# Altium schematic sheet BMS.SchDoc
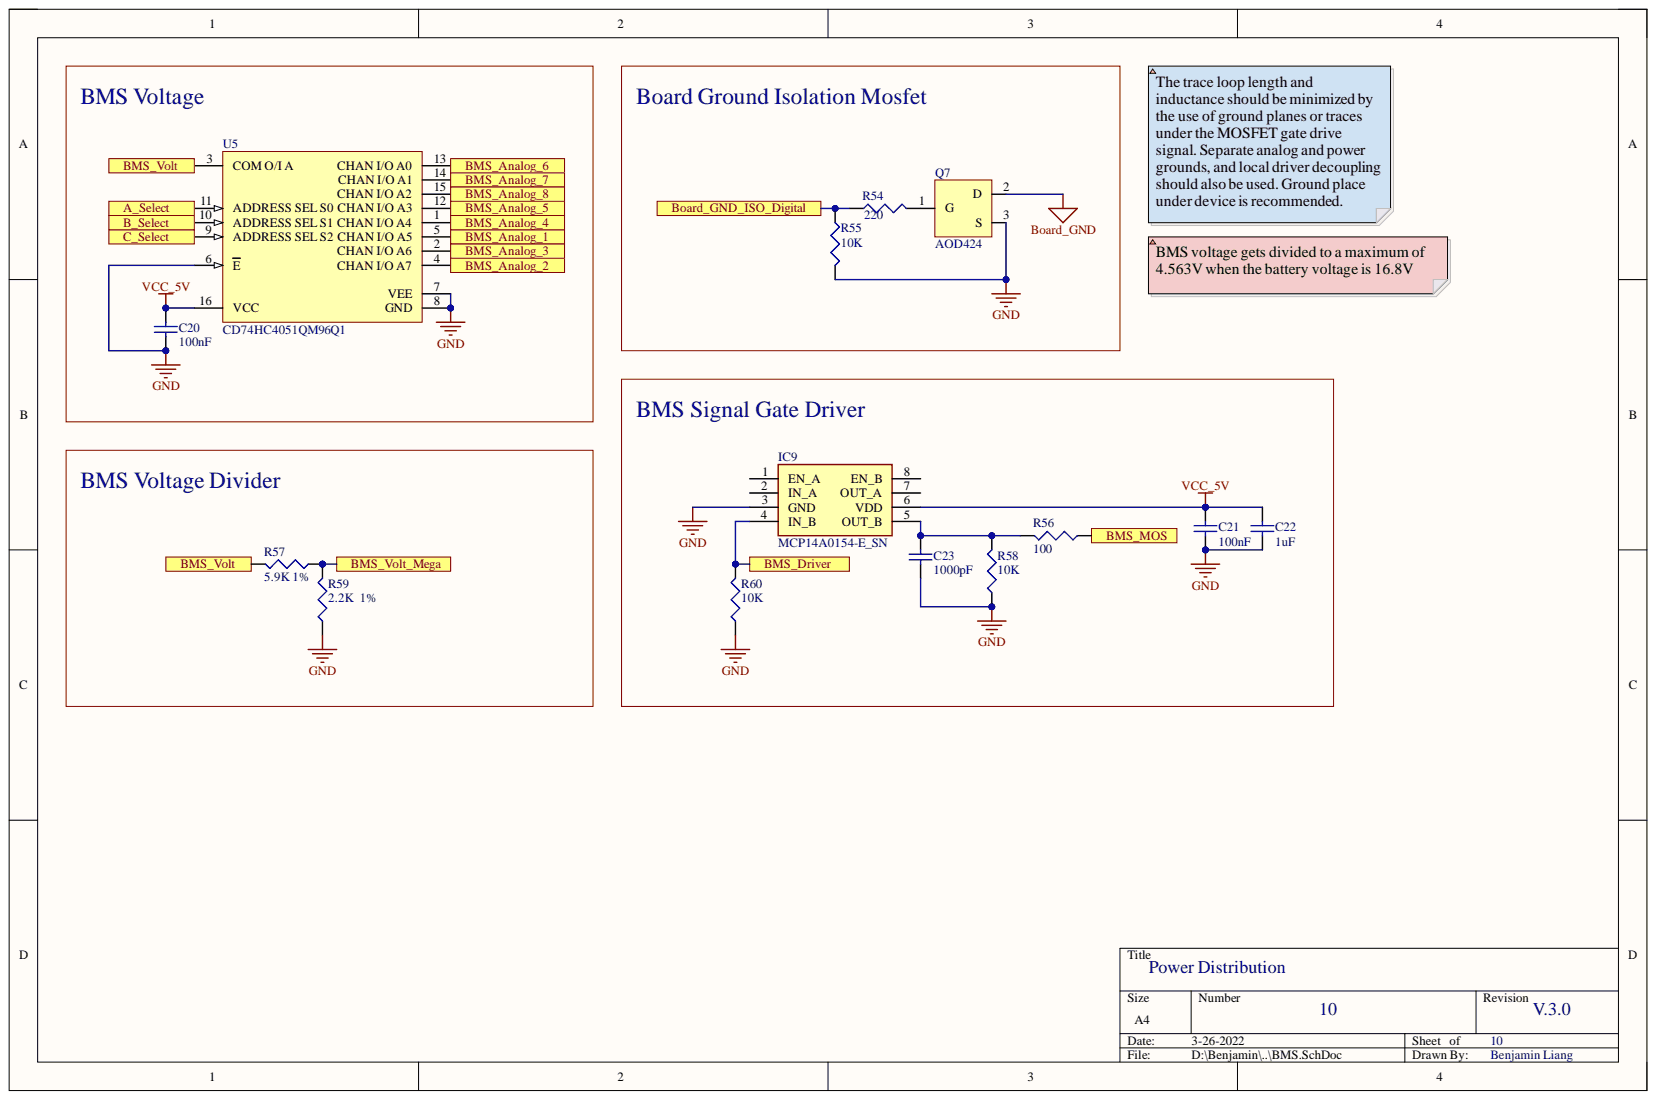
[diagram p0: BMS.SchDoc]

## Parts
C20  100nF  [C1206]
C21  100nF  [C1206]
C22  1uF  value 100nF  [C1206]
C23  1000pF  [C1206]
IC9  MCP14A0154-E_SN  [SOIC127P599X173-8N]
Q7  AOD424  [DPAK228P998X240-3N]
R54  220  [J1-0603]
R55  10K  [J1-0603]
R56  100  [J1-0603]
R57  5.9K 1%  [J1-0603]
R58  10K  [J1-0603]
R59  2.2K  1%  [J1-0603]
R60  10K  [J1-0603]
U5  CD74HC4051QM96Q1  [D0016A_L]

## Ports
A_Select, B_Select, BMS_Analog_1, BMS_Analog_2, BMS_Analog_3, BMS_Analog_4, BMS_Analog_5, BMS_Analog_6, BMS_Analog_7, BMS_Analog_8, BMS_Driver, BMS_MOS, BMS_Volt, BMS_Volt_Mega, Board_GND_ISO_Digital, C_Select

## Nets
Board_GND: Q7.2
GND: C20.2 C21.2 C22.2 C23.2 IC9.3(GND) Q7.3 R55.2 R58.1 R59.1 R60.1 U5.6(E) U5.7(VEE) U5.8(GND)
VCC_5V: C20.1 C21.1 C22.1 IC9.6(VDD) U5.16(VCC)
A_Select: U5.11 ; port A_Select
B_Select: U5.10 ; port B_Select
BMS_Analog_1: U5.5 ; port BMS_Analog_1
BMS_Analog_2: U5.4(CHAN_I/O_A7) ; port BMS_Analog_2
BMS_Analog_3: U5.2(CHAN_I/O_A6) ; port BMS_Analog_3
BMS_Analog_4: U5.1 ; port BMS_Analog_4
BMS_Analog_5: U5.12 ; port BMS_Analog_5
BMS_Analog_6: U5.13(CHAN_I/O_A0) ; port BMS_Analog_6
BMS_Analog_7: U5.14(CHAN_I/O_A1) ; port BMS_Analog_7
BMS_Analog_8: U5.15(CHAN_I/O_A2) ; port BMS_Analog_8
BMS_Driver: IC9.4(IN_B) R60.2 ; port BMS_Driver
BMS_MOS: R56.1 ; port BMS_MOS
BMS_Volt: R57.2 U5.3(COM_O/I_A) ; port BMS_Volt
BMS_Volt_Mega: R57.1 R59.2 ; port BMS_Volt_Mega
Board_GND_ISO_Digital: R54.1 R55.1 ; port Board_GND_ISO_Digital
C_Select: U5.9 ; port C_Select
NetC23_1: C23.1 IC9.5(OUT_B) R56.2 R58.2
NetQ7_1: Q7.1 R54.2

Not connected: IC9 1(EN_A) 2(IN_A) 7(OUT_A) 8(EN_B)

## Library data of the parts
C20-C22 = Cap Semi: Capacitor (Semiconductor SIM Model); Component Kind: Standard; LatestRevisionDate: 29-May-2009; LatestRevisionNote: IPC-7351 Footprint Added.; Library Name: Miscellaneous Devices.IntLib; PackageDescription: Chip Capacitor; PackageReference: C1206; Published: 8-Jun-2000; Signal Integrity: Cap Semi; Simulation: CAP; Publisher: Altium Limited
  C20 C21: Value: 100nF
C23 = Cap Semi: Capacitor (Semiconductor SIM Model); Value: 100nF; Component Kind: Standard; LatestRevisionDate: 29-May-2009; LatestRevisionNote: IPC-7351 Footprint Added.; Library Name: Miscellaneous Devices.IntLib; PackageDescription: Chip Capacitor; PackageReference: C1206; Published: 8-Jun-2000; Signal Integrity: Cap Semi; Simulation: CAP; Publisher: Altium Limited
IC9 = MCP14A0154-E_SN: Integrated Circuit; Manufacturer_Name: Microchip; Manufacturer_Part_Number: MCP14A0154-E/SN; Arrow Part Number: MCP14A0154-E/SN; Arrow Price/Stock: https://www.arrow.com/en/products/mcp14a0154-esn/microchip-technology?region=nac; Component Kind: Standard; Datasheet Link: http://www.microchip.com/mymicrochip/filehandler.aspx?ddocname=en584525; Height: 1.1mm; Mouser Part Number: 579-MCP14A0154-E/SN; Mouser Price/Stock: https://www.mouser.co.uk/ProductDetail/Microchip-Technology/MCP14A0154-E-SN?qs=BA62vJVifGqWAZTNBysjlw%3D%3D
R54 = Res3: Resistor; Value: 10K; Component Kind: Standard; LatestRevisionDate: 29-May-2009; LatestRevisionNote: IPC-7351 Footprint Added.; Library Name: Miscellaneous Devices.IntLib; PackageDescription: Chip Resistor; PackageReference: J1-0603; Published: 8-Jun-2000; Signal Integrity: Res; Simulation: RESISTOR; Publisher: Altium Limited
R55 = Res3: Resistor; Component Kind: Standard; LatestRevisionDate: 29-May-2009; LatestRevisionNote: IPC-7351 Footprint Added.; Library Name: Miscellaneous Devices.IntLib; PackageDescription: Chip Resistor; PackageReference: J1-0603; Published: 8-Jun-2000; Signal Integrity: Res; Simulation: RESISTOR; Value: 10K; Publisher: Altium Limited
R56 R58 = Res3: Resistor; Value: 47K; Component Kind: Standard; LatestRevisionDate: 29-May-2009; LatestRevisionNote: IPC-7351 Footprint Added.; Library Name: Miscellaneous Devices.IntLib; PackageDescription: Chip Resistor; PackageReference: J1-0603; Published: 8-Jun-2000; Signal Integrity: Res; Simulation: RESISTOR; Publisher: Altium Limited
R57 R59 = Res3: Resistor; Value: 220; Component Kind: Standard; LatestRevisionDate: 29-May-2009; LatestRevisionNote: IPC-7351 Footprint Added.; Library Name: Miscellaneous Devices.IntLib; PackageDescription: Chip Resistor; PackageReference: J1-0603; Published: 8-Jun-2000; Signal Integrity: Res; Simulation: RESISTOR; Publisher: Altium Limited
R60 = Res3: Resistor; Value: 4.7K; Component Kind: Standard; LatestRevisionDate: 29-May-2009; LatestRevisionNote: IPC-7351 Footprint Added.; Library Name: Miscellaneous Devices.IntLib; PackageDescription: Chip Resistor; PackageReference: J1-0603; Published: 8-Jun-2000; Signal Integrity: Res; Simulation: RESISTOR; Publisher: Altium Limited
U5 = CMP-1575-00013-4: Automotive Catalog High Speed CMOS Logic Analog Multiplexers/Demultiplexers, D0016A, LARGE T&R; Generic Part Number: CD74HC4051-Q1; Manufacturer: Texas Instruments; Bandwidth(Max)(MHz): 180; Configuration: 1 X 1：8 MUX; Crosstalk: Yes; Ron(Max)(Ohms): 130; Ron(Typ)(Ohms): 45; VCC(Max)(V): 6; VCC(Min)(V): 2; Code_JEDEC: MS-012 variation AC; Component Kind: Standard; DatasheetVersion: SCLS552A; Library Name: Altium Content Vault; Operating Temperature Range(C): -40 to 125; Output Type: CMOS; PackageReference: D0016A; PackageVersion: http://www.ti.com/lit/ml/mpds178g/mpds178g.pdf; Rating: Automotive
Q7 = AOD424: Component Kind: Standard; Library Name: Schlib1.SchLib
